# Altium schematic sheet Power_Supply.SchDoc(Power_Supply)
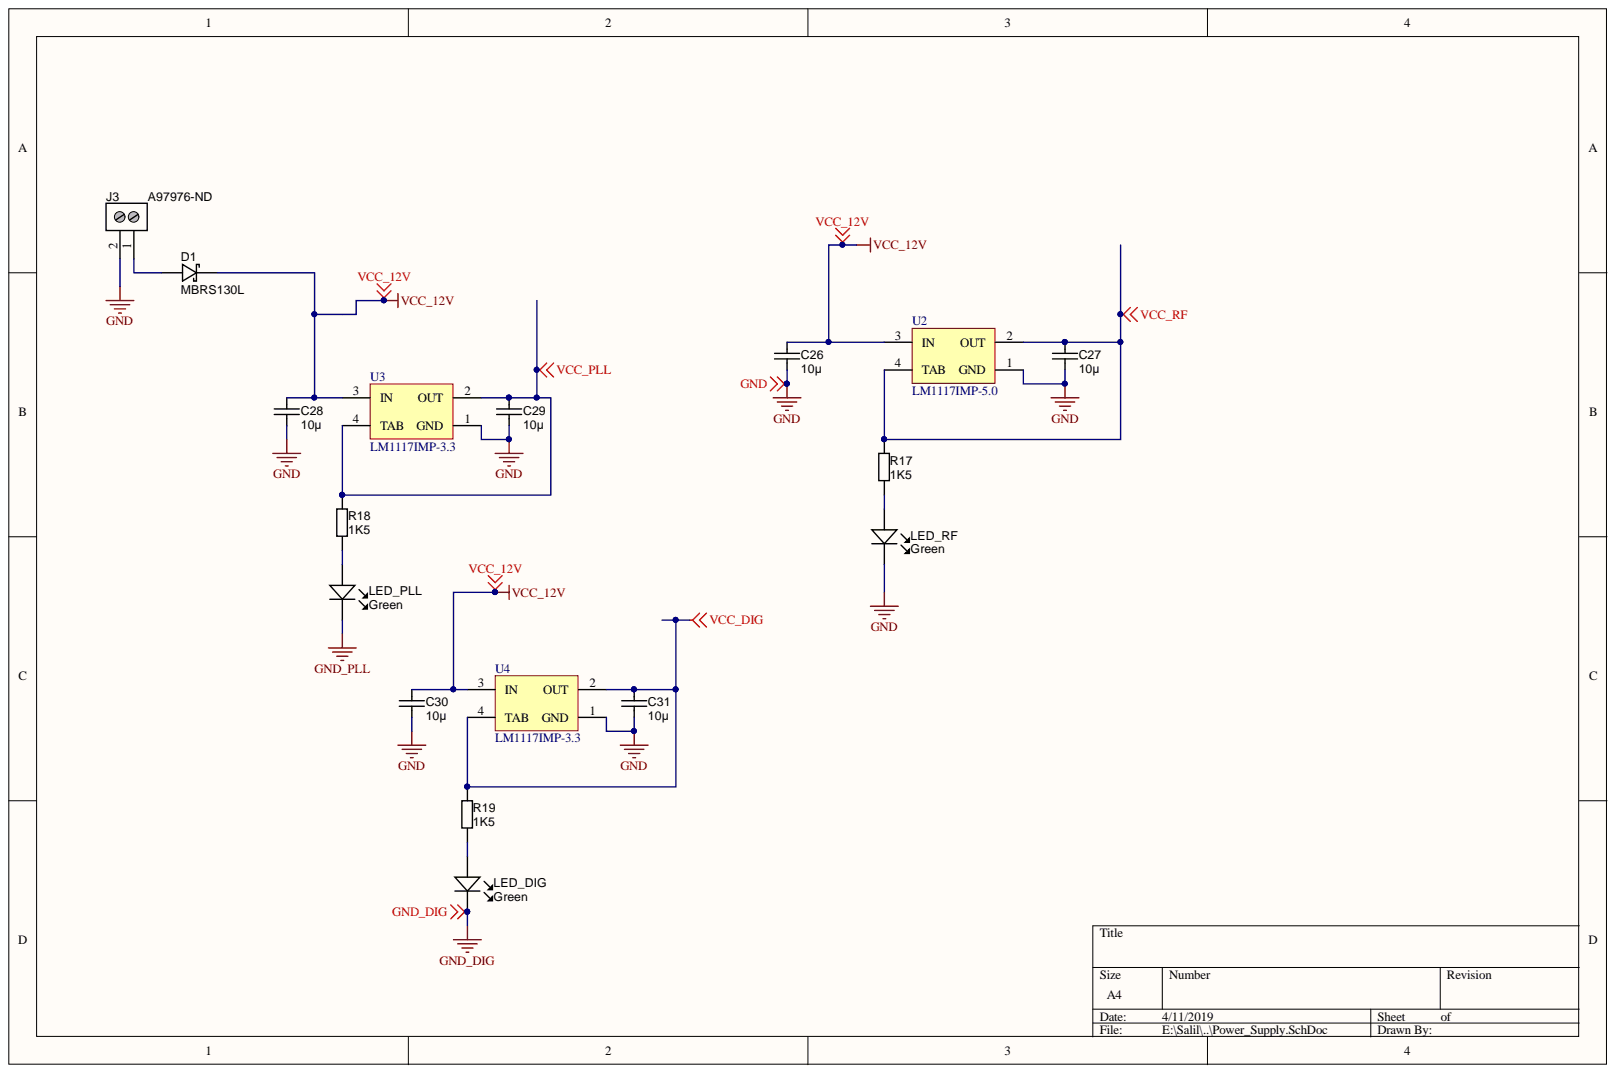
[diagram p0: Power_Supply.SchDoc]

## Parts
C26  value 10µ  [CAPC3216X180N]  (hidden comment: approved sub OK)
C27  value 10µ  [CAPC3216X180N]  (hidden comment: approved sub OK)
C28  value 10µ  [CAPC3216X180N]  (hidden comment: approved sub OK)
C29  value 10µ  [CAPC3216X180N]  (hidden comment: approved sub OK)
C30  value 10µ  [CAPC3216X180N]  (hidden comment: approved sub OK)
C31  value 10µ  [CAPC3216X180N]  (hidden comment: approved sub OK)
D1  MBRS130L  [DO-214AA]
J3  Supplier Part Number 1: A97976-ND  [2P_PCB_ScrewTerm_90S_3.81MM]  (hidden comment: Stackable ScrewTerm)
LED_DIG  Green  [LED-0603-GREEN]
LED_PLL  Green  [LED-0603-GREEN]
LED_RF  Green  [LED-0603-GREEN]
R17  value 1K5  [RESC1608X55N]  (hidden comment: Thick Film)
R18  value 1K5  [RESC1608X55N]  (hidden comment: Thick Film)
R19  value 1K5  [RESC1608X55N]  (hidden comment: Thick Film)
U2  LM1117IMP-5.0  [SOT223_L]
U3  LM1117IMP-3.3  [SOT223_L]
U4  LM1117IMP-3.3  [SOT223_L]

## Nets
GND: C26.2 C27.2 C28.2 C29.2 C30.2 C31.2 J3.2 LED_RF.K U2.1(GND) U3.1(GND) U4.1(GND)
GND_DIG: LED_DIG.K
GND_PLL: LED_PLL.K
VCC_12V: C26.1 C28.1 C30.1 D1.K U2.3(IN) U3.3(IN) U4.3(IN)
VCC_DIG: C31.1 R19.1 U4.2(OUT) U4.4(TAB)
VCC_PLL: C29.1 R18.1 U3.2(OUT) U3.4(TAB)
VCC_RF: C27.1 R17.1 U2.2(OUT) U2.4(TAB)
NetD1_A: D1.A J3.1
NetLED_DIG_A: LED_DIG.A R19.2
NetLED_PLL_A: LED_PLL.A R18.2
NetLED_RF_A: LED_RF.A R17.2

## Library data of the parts
C26-C31 = CAPC_10u_1206_16V: Capacitor SMD Cer 10uF 10% 16V X7R 1206; Manufacturer Part Number 1: C1206C106K4RACTU; Manufacturer 1: Kemet; SCDType: spec; Ratings: 16V; X7R; Material: ceramic; Tolerance: ±10%; Supplier Part Number 1: 399-3525-1-ND; Supplier 1: Digi-Key; Package / Case: 1206 (3216 metric); Library Name: PBL - Capacitors.SCHLIB; Component Kind: Standard
D1 = DIODE_SCHOTTKY_MBRS130L: Diode Schottky SMD 30V 2A DO-214AA; Manufacturer 1: Fairchild Semiconductor; SCDType: source; Ratings: 30Vr; 2A; Material: Si; Supplier Part Number 1: MBRS130LFSCT-ND; Supplier 1: Digi-Key; Package / Case: DO-214AA, SMB; Manufacturer Part Number 1: MBRS130L; Library Name: PBL - Diodes.SCHLIB; Component Kind: Standard
J3 = 2P_ScrewTerm_90S_3.81MM: Terminal Block Screw Type THT 2-Position 3.81mm pitch; Manufacturer Part Number 1: 1776113-2; Manufacturer 1: Tyco Electronics; Value: 2 position; SCDType: undef; Positions Per Level: 2; Pitch: 0.150" (3.81mm); Supplier 1: Digi-Key; Package / Case: Side Entry; 3.81mm pitch; Library Name: PBL - Connectors.SCHLIB; Component Kind: Standard; Color: Black; Category: Connectors Interconnects
LED_DIG LED_PLL LED_RF = LED_SMD_Green_0603_570nm: LED 0603 SMD Green 570nm 50mcd 20mA; Manufacturer Part Number 1: APT1608CGCK; Manufacturer 1: Kingbright Company LLC; Wavelength - Peak: 574nm; Voltage - Forward (Vf) Typ: 2.1V; Voltage - Forward (Vf) @ 10mA: 2.0V; Viewing Angle: 120°; SCDType: source; Ratings: 20mcd min; 50 mcd typ; 570 nm; Lens Type: Clear; Lens Style/Size: Rectangle with Flat Top, 1.1mm x 0.8mm; Wavelength - Dominant: 570nm; Supplier Part Number 1: 754-1116-1-ND; Supplier 1: Digi-Key; RoHS 1: RoHS Compliant; Package / Case: 0603 (1608 metric); Material: AlGaInP; Library Name: PBL - Light Sources.SCHLIB; Current - Test: 20mA; ComponentLink1URL: http://www.us.kingbright.com/images/catalog/SPEC/APT1608CGCK.pdf; ComponentLink1Description: http://www.us.kingbright.com/images/catalog/SPEC/APT1608CGCK.pdf; Component Kind: Standard; Color: Green
R17-R19 = RES_1K5_0603_5%: Resistor SMD chip 1.5k Ohm 0.1W 5% 0603; Manufacturer Part Number 1: RC0603JR-071K5L; Manufacturer 1: Yageo; SCDType: spec; Ratings: 1/10 W; Tolerance: ±5%; Supplier Part Number 1: 311-1.5KGRCT-ND; Supplier 1: Digi-Key; Package / Case: 0603 (1608 metric); Material: thick film; Library Name: PBL - Resistors.SCHLIB; Component Kind: Standard
U2 = LM1117IMP-5.0: 800mA Low-Dropout Linear Regulator, 4-pin SOT-223; Manufacturer: National Semiconductor; PowerWise: N; PackageTopMarking: XYTT*N06B; CompType: LDO IC; Supplier Part Number 1: 7614135; Supplier 1: Farnell; RoHS: N; Published: 4-Dec-2008; PinCount: 4; PartNumber: LM1117IMP-5.0; PackageVersion: revB, Apr-2003; PackageReference: MP04A; PackageDescription: Molded SOT-223, 6.50 x 3.56 x 1.6mm Body, 4 Lead, 2.3mm Pitch; Library Name: NSC LDO.IntLib; DatasheetVersion: Apr-2006; ComponentLink3URL: http://www.national.com/packaging/folders/mp04a.html; ComponentLink3Description: MP04A Package Page; ComponentLink2URL: http://www.national.com/pf/LM/LM1117.html; ComponentLink2Description: LM1117 Web Page; ComponentLink1URL: http://www.national.com/ds/LM/LM1117.pdf; ComponentLink1Description: LM1117 Datasheet; Component Kind: Standard; Code_JEDEC: TO-261-AA; Code_IPC: SOT223-4; Publisher: Altium Limited
U3 U4 = LM1117IMP-3.3: 800mA Low-Dropout Linear Regulator, 4-pin SOT-223; Manufacturer: National Semiconductor; PowerWise: N; PackageTopMarking: XYTT*N05B; CompType: LDO IC; Supplier Part Number 1: 7614123; Supplier 1: Farnell; RoHS: N; Published: 4-Dec-2008; PinCount: 4; PartNumber: LM1117IMP-3.3; PackageVersion: revB, Apr-2003; PackageReference: MP04A; PackageDescription: Molded SOT-223, 6.50 x 3.56 x 1.6mm Body, 4 Lead, 2.3mm Pitch; Library Name: NSC LDO.IntLib; DatasheetVersion: Apr-2006; ComponentLink3URL: http://www.national.com/packaging/folders/mp04a.html; ComponentLink3Description: MP04A Package Page; ComponentLink2URL: http://www.national.com/pf/LM/LM1117.html; ComponentLink2Description: LM1117 Web Page; ComponentLink1URL: http://www.national.com/ds/LM/LM1117.pdf; ComponentLink1Description: LM1117 Datasheet; Component Kind: Standard; Code_JEDEC: TO-261-AA; Code_IPC: SOT223-4; Publisher: Altium Limited
